# Altium schematic sheet Power.SchDoc
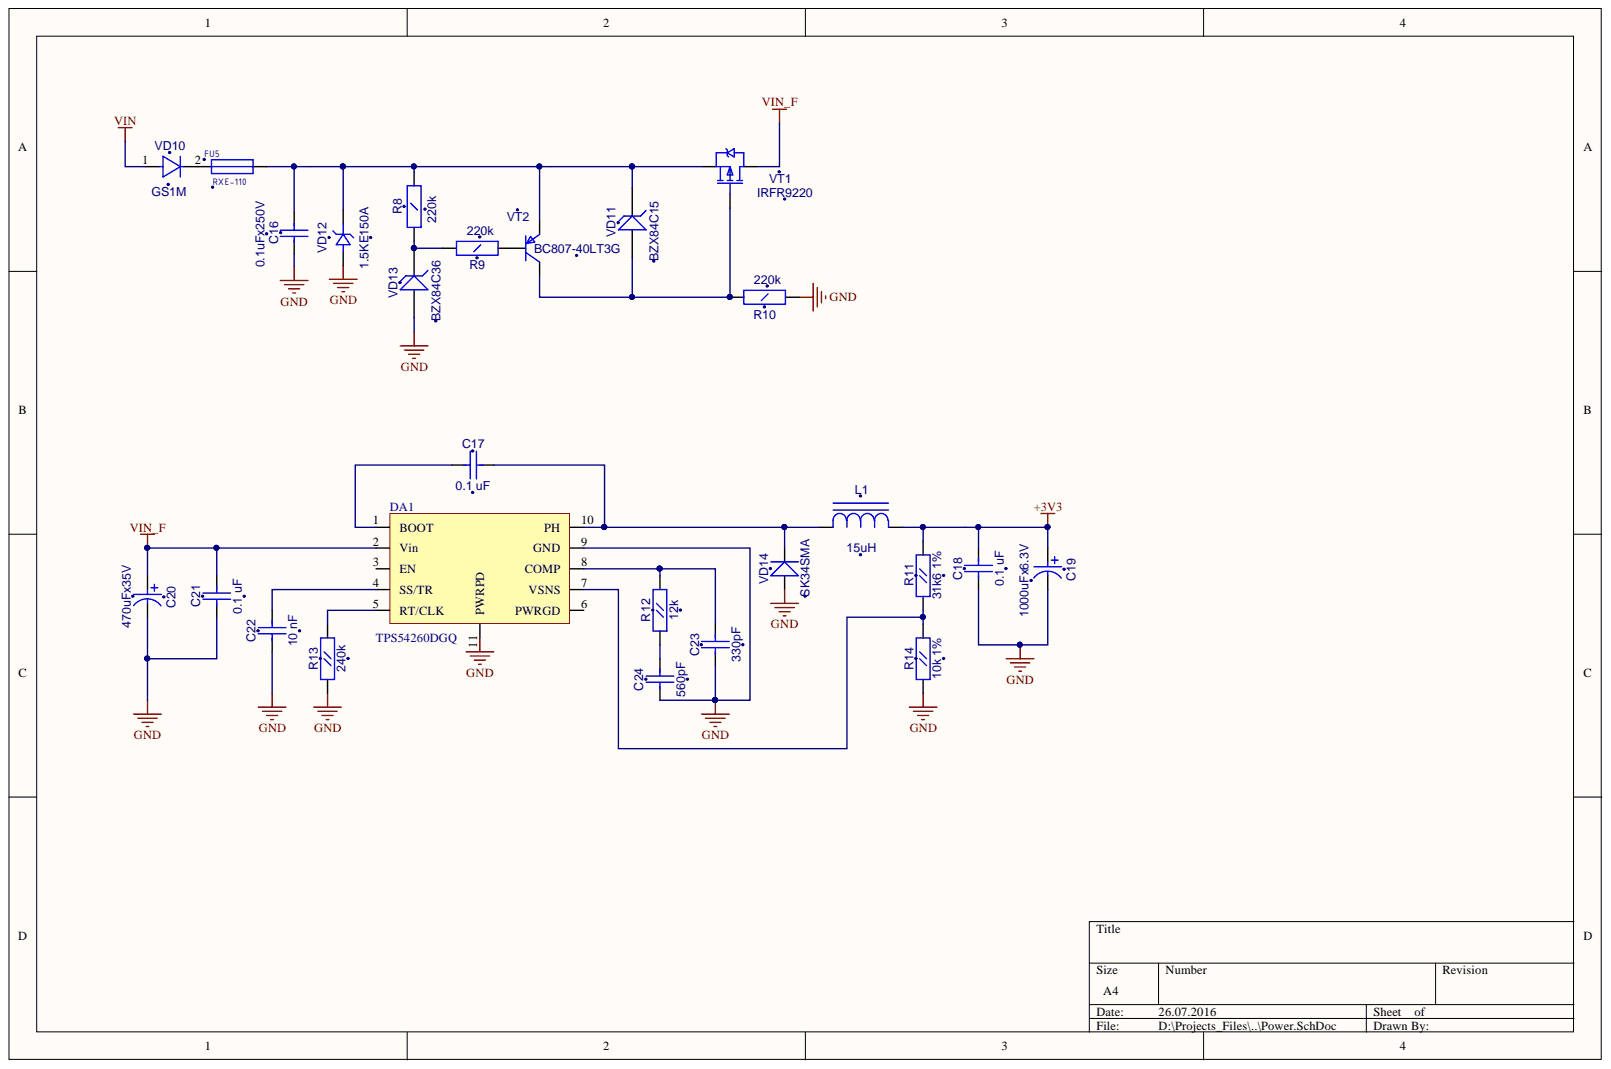
[diagram p0: Power.SchDoc]

## Parts
C16  0.1uFx250V  [CC1206]
C17  0.1 uF  [CC0603]
C18  0.1 uF  [CC0603]
C19  1000uFx6.3V  [CC8X3.5]
C20  470uFx35V  [CC16X10_CAP0.5(1)(Primary)]
C21  0.1 uF  [CC0603]
C22  10 nF  [CC0603]
C23  330pF  [CC0603]
C24  560pF  [CC0603]
DA1  TPS54260DGQ  [S-PDSO-G10]
FU5  RXE-110  [RXE-110]
L1  15uH  [SDR0805]
R8  220k  [RC1206]
R9  220k  [RC1206]
R10  220k  [RC1206]
R11  31k6 1%  [RC0603]
R12  12k  [RC0603]
R13  240k  [RC0603]
R14  10k 1%  [RC0603]
VD10  GS1M  [SMA]
VD11  BZX84C15  [SOT-23(123)]
VD12  1.5KE150A  [DO-201]
VD13  BZX84C36  [SOT-23(123)]
VD14  SK34SMA  [SMA]
VT1  IRFR9220  [D-PAK(TO-252AA)]
VT2  BC807-40LT3G  [SOT-23(123)]

## Nets
+3V3: C18.1 C19.1 L1.2 R11.2
GND: C16.1 C18.2 C19.2 C20.1 C21.2 C22.2 C23.2 C24.2 DA1.9(GND) DA1.11(PWRPD) R10.1 R13.1 R14.1 VD12.1 VD13.1 VD14.1
VIN: VD10.1
VIN_F: C20.2 C21.1 DA1.2(Vin) VT1.3
NetC16_2: C16.2 FU5.2 R8.2 VD11.3 VD12.2 VT1.2 VT2.2
NetC17_1: C17.1 DA1.10(PH) L1.1 VD14.2
NetC17_2: C17.2 DA1.1(BOOT)
NetC22_1: C22.1 DA1.4(SS/TR)
NetC23_1: C23.1 DA1.8(COMP) R12.2
NetC24_1: C24.1 R12.1
NetDA1_5: DA1.5(RT/CLK) R13.2
NetDA1_7: DA1.7(VSNS) R11.1 R14.2
NetFU5_1: FU5.1 VD10.2
NetR8_1: R8.1 R9.2 VD13.3
NetR9_1: R9.1 VT2.1
NetR10_2: R10.2 VD11.1 VT1.1 VT2.3

Not connected: DA1 3(EN) 6(PWRGD)

## Library data of the parts
C16 = CC1206: Component Kind: Standard; Description: CC1206; Value: 0.1uFx250V; Library Name: gprs_modem_2.SchLib
C17 C18 C21-C24 = CC0603: Component Kind: Standard; Description: CC0603; Library Name: gprs_modem_2.SchLib
  C17 C18 C21: Value: 0.1 uF
  C22: Value: 10 nF
  C23: Value: 330pF
  C24: Value: 560pF
C19 = CAP0.35: Component Kind: Standard; Description: CAP0.35; Value: 1000uFx6.3V; Library Name: gprs_modem_2.SchLib
C20 = CAP0.5(1): Component Kind: Standard; Description: CAP0.5(1); Value: 470uFx35V; Library Name: gprs_modem_2.SchLib
L1 = SDR0805: Component Kind: Standard; Description: SDR0805; Value: 15uH; Library Name: gprs_modem.SchLib
R8-R10 = RC1206: Component Kind: Standard; Description: RC1206; Value: 220k; Library Name: gprs_modem_2.SchLib
R11-R14 = RC0603: Component Kind: Standard; Description: RC0603; Library Name: gprs_modem_2.SchLib
  R11: Value: 31k6 1%
  R12: Value: 12k
  R13: Value: 240k
  R14: Value: 10k 1%
VD10 = GS1M: Value: {Value}; Component Kind: Standard; Description: GS1M; Library Name: Schetchik.SchLib
VD11 VD13 = BZX84: Zener Voltage Regulator Diode; Component Kind: Standard; Library Name: gprs_modem_2.SchLib
  VD11: Value: BZX84C15
  VD13: Value: BZX84C36
VD12 = 1.5KE: Component Kind: Standard; Description: 1.5KE; Value: 1.5KE150A; Library Name: gprs_modem.SchLib
VD14 = SK34SMA: Schottky Rectifier; Value: {Value}; Component Kind: Standard; Library Name: gprs_modem.SchLib
VT1 = IRFR9220: HEXFET Power MOSFET; ComponentField7: Published 8-Jun-2000; OriginalName: IRLML5103; Component Kind: Standard; Value: IRFR9220; Library Name: gprs_modem.SchLib
VT2 = BC856B: ComponentField7: Published 29-Sep-2000; OriginalName: BC856BLT; Component Kind: Standard; Description: BC856B; Simulation: BC846BLT1; Value: BC807-40LT3G; Library Name: Schetchik.SchLib
DA1 = TPS54260DGQ: Component Kind: Standard; Library Name: gprs_modem.SchLib
FU5 = RXE-110: Component Kind: Standard; Description: RXE-110; Library Name: gprs_modem_2.SchLib
